# Altium schematic sheet MCU&Connector V1.0.SchDoc
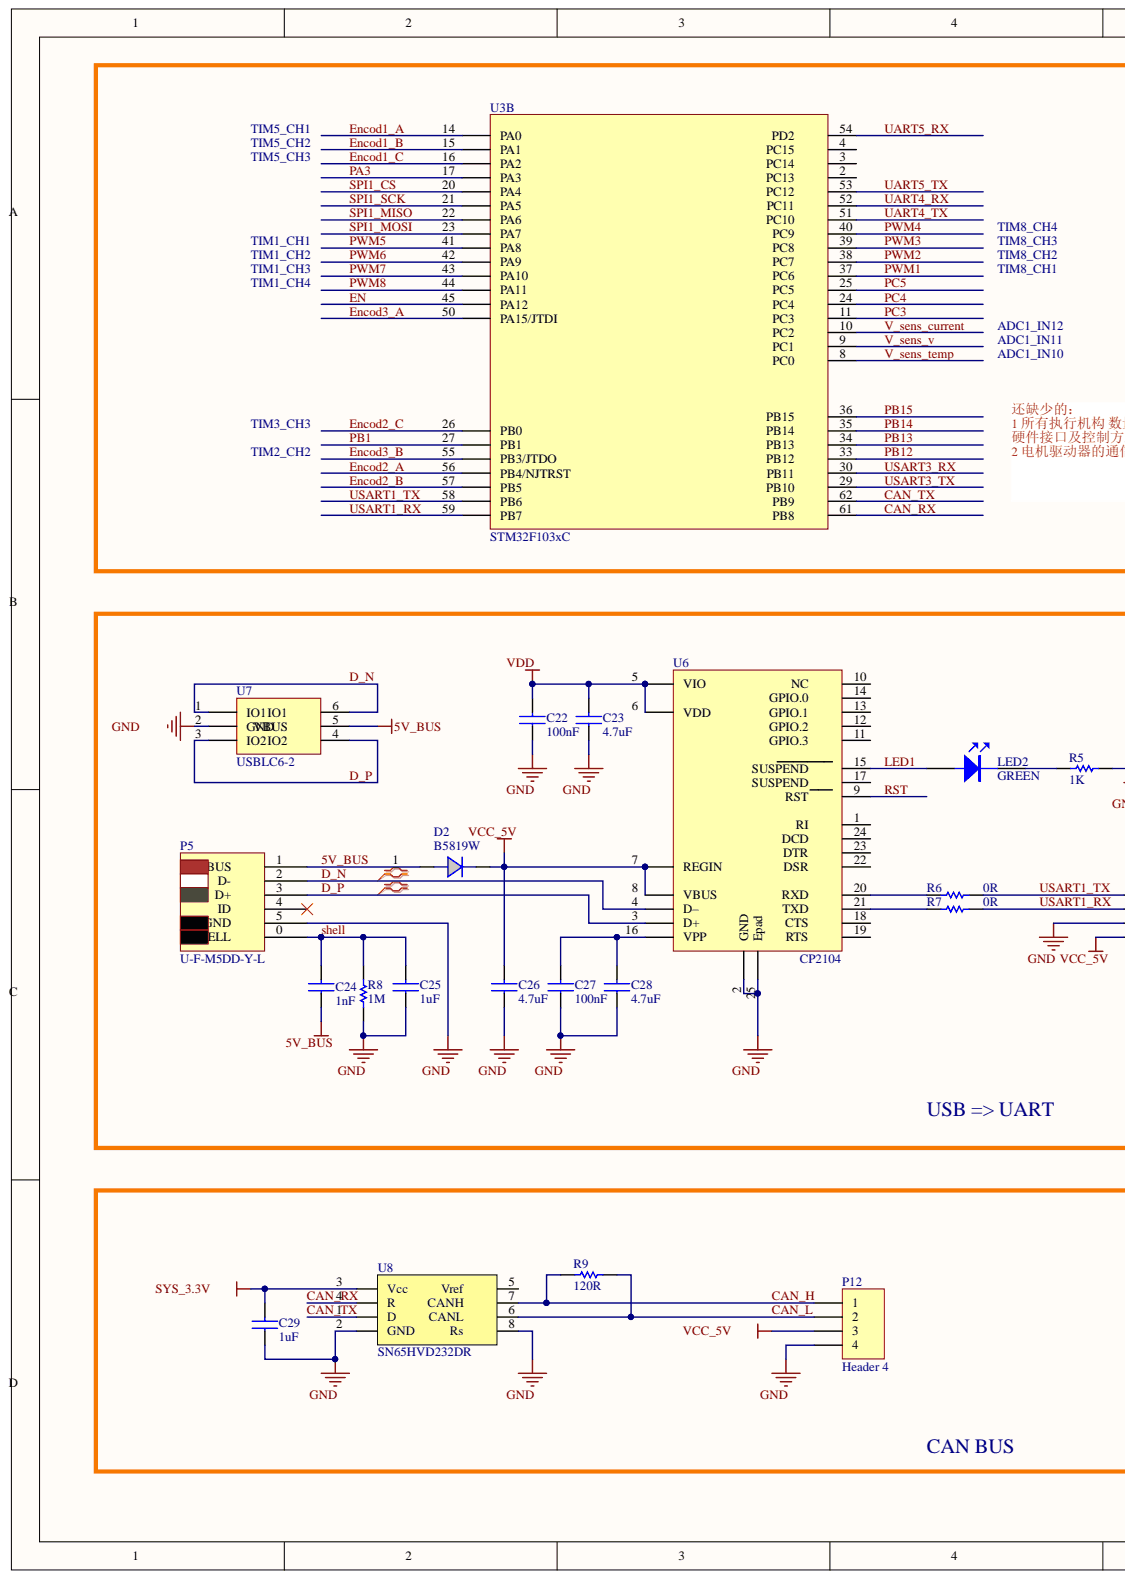
[diagram p0: MCU&Connector V1.0.SchDoc, left]
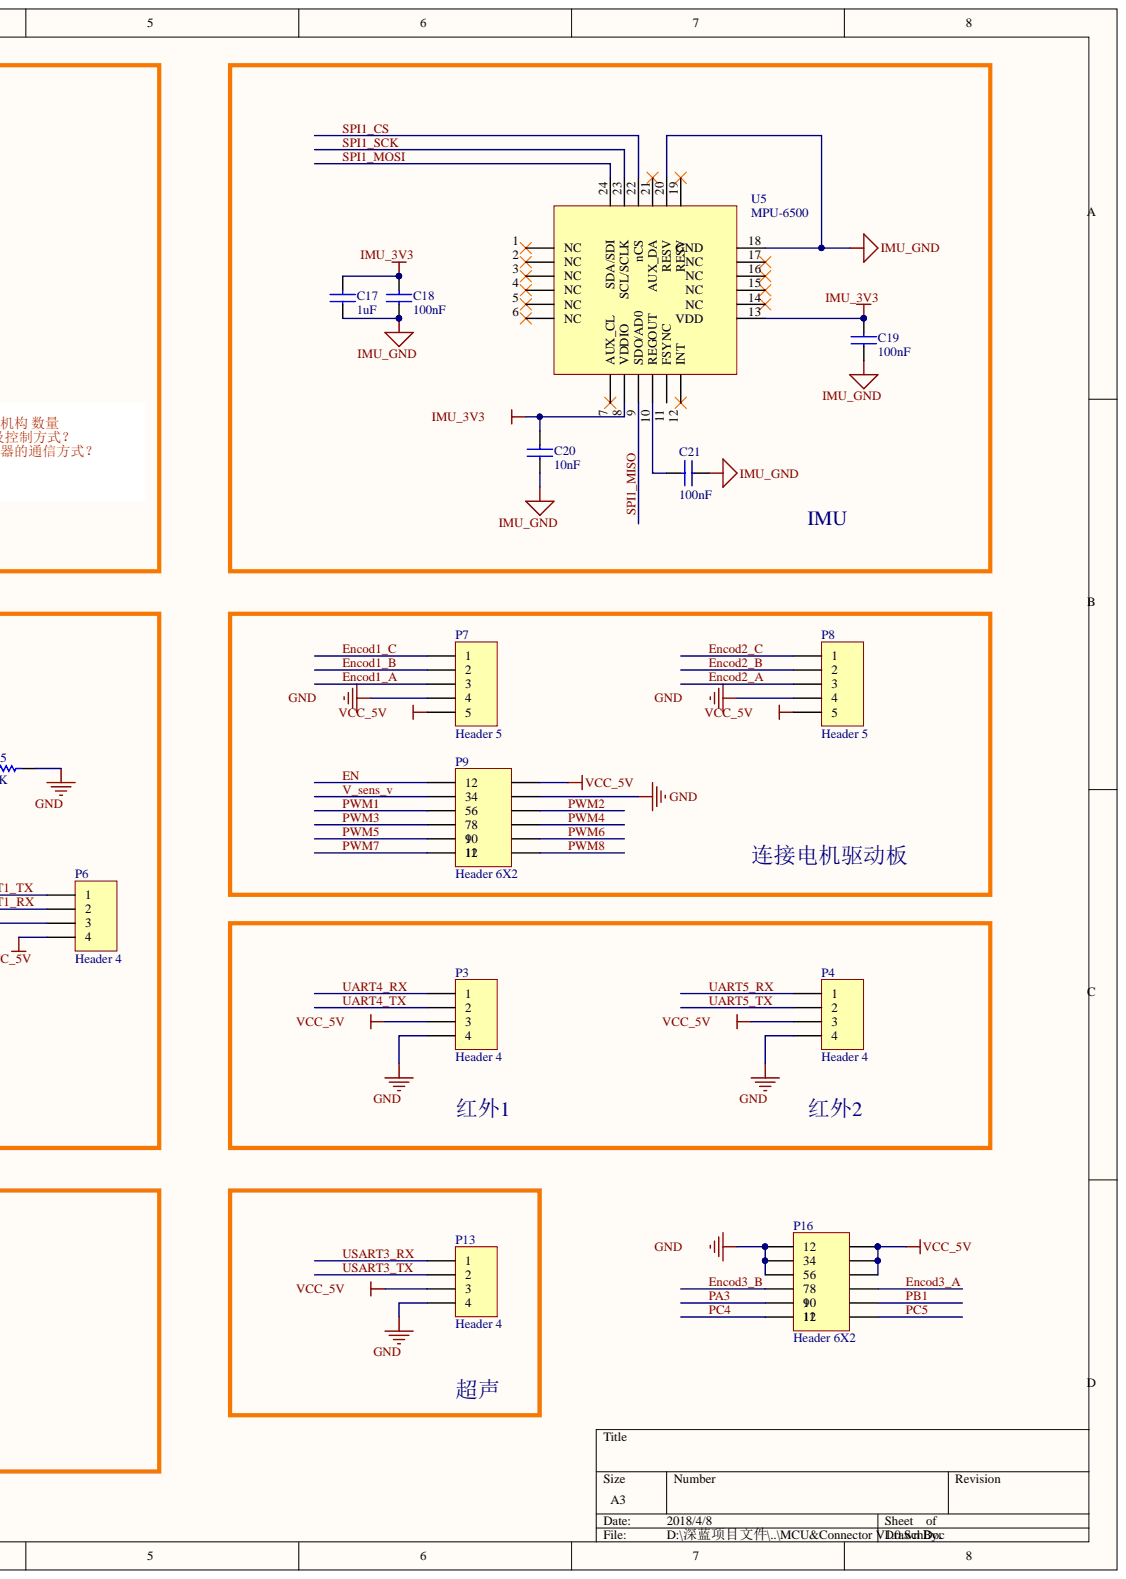
[diagram p1: MCU&Connector V1.0.SchDoc, right]

## Parts
C17  1uF  [CAPC1608N]
C18  100nF  [CAPC1608N]
C19  100nF  [CAPC1608N]
C20  10nF  [CAPC1608N]
C21  100nF  [CAPC1608N]
C22  100nF  [CAPC1608N]
C23  4.7uF  [CAPC1608N]
C24  1nF  [CAPC1608N]
C25  1uF  [CAPC1608N]
C26  4.7uF  [CAPC1608N]
C27  100nF  [CAPC1608N]
C28  4.7uF  [CAPC1608N]
C29  1uF  [CAPC1608N]
D2  B5819W  [SOD-323]
LED2  GREEN  [LED_0603]
P3  Header 4  [1.25-4P-WT]
P4  Header 4  [1.25-4P-WT]
P5  value U-F-M5DD-Y-L  [USB_MICRO]  (hidden comment: USB_MICRO)
P6  Header 4  [HDR1X4]
P7  Header 5  [S5B-XH-A-1]
P8  Header 5  [S5B-XH-A-1]
P9  Header 6X2  [HDR2X6]
P12  Header 4  [1.25-4P-WT]
P13  Header 4  [1.25-4P-WT]
P16  Header 6X2  [HDR2X6]
R5  value 1K  [RESC1608N]
R6  value 0R  [RESC1608N]
R7  value 0R  [RESC1608N]
R8  value 1M  [RESC1608N]
R9  value 120R  [RESC1608N]
U3  STM32F103xC  [TSQFP50P1200X1200-64M]  (part B)
U5  MPU-6500  [MPU6500]
U6  CP2104  [QFN50P400X400X80_HS-25N]
U7  USBLC6-2  [SOT23-6]
U8  SN65HVD232DR  [SOIC8]

## Nets
GND: C22.1 C23.1 C25.1 C26.1 C27.1 C28.1 C29.1 P3.4 P4.4 P5.5 P6.3 P7.4 P8.4 P9.4 P12.4 P13.4 P16.1 P16.3 P16.5 R5.2 R8.1 U6.2(GND) U6.25(Epad) U7.2(GND) U8.2(GND) U8.8
IMU_3V3: C17.2 C18.2 C19.2 C20.2 U5.8(VDDIO) U5.13
IMU_GND: C17.1 C18.1 C19.1 C20.1 C21.1 U5.18 U5.20
SYS_3.3V: C29.2 U8.3(Vcc)
VCC_5V: C26.2 D2.2 P3.3 P4.3 P6.4 P7.5 P8.5 P9.2 P12.3 P13.3 P16.2 P16.4 P16.6 U6.7(REGIN) U6.8(VBUS)
VDD: C22.2 C23.2 U6.5(VIO) U6.6(VDD)
5V_BUS: C24.1 D2.1 P5.1 U7.5
CAN_H: P12.1 R9.2 U8.7
CAN_L: P12.2 R9.1 U8.6
CAN_RX: U3.61 U8.4(R)
CAN_TX: U3.62 U8.1(D)
D_N: P5.2 U6.4(D–) U7.1(IO1_IO1) U7.6
D_P: P5.3 U6.3(D+) U7.3(IO2_IO2) U7.4
EN: P9.1 U3.45(PA12)
ENCOD1_A: P7.3 U3.14(PA0)
ENCOD1_B: P7.2 U3.15(PA1)
ENCOD1_C: P7.1 U3.16(PA2)
ENCOD2_A: P8.3 U3.56(PB4/NJTRST)
ENCOD2_B: P8.2 U3.57(PB5)
ENCOD2_C: P8.1 U3.26(PB0)
ENCOD3_A: P16.8 U3.50(PA15/JTDI)
ENCOD3_B: P16.7 U3.55(PB3/JTDO)
LED1: LED2.1 U6.15
PA3: P16.9 U3.17(PA3)
PB1: P16.10 U3.27(PB1)
PB12: U3.33
PB13: U3.34
PB14: U3.35
PB15: U3.36
PC3: U3.11
PC4: P16.11 U3.24
PC5: P16.12 U3.25
PWM1: P9.5 U3.37
PWM2: P9.6 U3.38
PWM3: P9.7 U3.39
PWM4: P9.8 U3.40
PWM5: P9.9 U3.41(PA8)
PWM6: P9.10 U3.42(PA9)
PWM7: P9.11 U3.43(PA10)
PWM8: P9.12 U3.44(PA11)
RST: U6.9
SHELL: C24.2 C25.2 P5.0 R8.2
SPI1_CS: U3.20(PA4) U5.22
SPI1_MISO: U3.22(PA6) U5.9(SDO/AD0)
SPI1_MOSI: U3.23(PA7) U5.24
SPI1_SCK: U3.21(PA5) U5.23
UART4_RX: P3.1 U3.52
UART4_TX: P3.2 U3.51
UART5_RX: P4.1 U3.54
UART5_TX: P4.2 U3.53
USART1_RX: P6.2 R7.2 U3.59(PB7)
USART1_TX: P6.1 R6.2 U3.58(PB6)
USART3_RX: P13.1 U3.30
USART3_TX: P13.2 U3.29
V_SENS_CURRENT: U3.10
V_SENS_TEMP: U3.8
V_SENS_V: P9.3 U3.9
NetC21_2: C21.2 U5.10(REGOUT)
NetC27_2: C27.2 C28.2 U6.16(VPP)
NetLED2_2: LED2.2 R5.1
NetR6_1: R6.1 U6.20
NetR7_1: R7.1 U6.21

Not connected: P5 4; U3 2 3 4; U5 1(NC) 2(NC) 3(NC) 4(NC) 5(NC) 6(NC) 7(AUX_CL) 11(FSYNC) 12(INT) 14 15 16 17 19 21; U6 1 10 11 12 13 14 17 18 19 22 23 24; U8 5

## Library data of the parts
C17-C29 = CAP: Component Kind: Standard; Description: CAP; Library Name: YUNEEC-SCH.lib
D2 = D_1N4148WS: Manufacturer: Diodes; Component Kind: Standard; Pin Count: 2; Supplier: SZ-JLC; value: B5819W; Library Name: F5-Abilix-v0.1.SCHLIB
LED2 = LIGHT: Component Kind: Standard; Library Name: YUNEEC-SCH.lib
P3 P4 P6 P12 P13 = Header 4: Header, 4-Pin; Component Kind: Standard; LatestRevisionDate: 17-Jul-2002; LatestRevisionNote: Re-released for DXP Platform.; Library Name: Miscellaneous Connectors.IntLib; Signal Integrity: Connector; Publisher: Altium Limited
P7 P8 = Header 5: Header, 5-Pin; Component Kind: Standard; LatestRevisionDate: 17-Jul-2002; LatestRevisionNote: Re-released for DXP Platform.; Library Name: Miscellaneous Connectors.IntLib; Signal Integrity: Connector; Publisher: Altium Limited
P9 P16 = Header 6X2: Header, 6-Pin, Dual row; Component Kind: Standard; LatestRevisionDate: 17-Jul-2002; LatestRevisionNote: Re-released for DXP Platform.; Library Name: Miscellaneous Connectors.IntLib; Signal Integrity: Connector; Publisher: Altium Limited
R5-R9 = Res1: Resistor; Component Kind: Standard; LatestRevisionDate: 17-Jul-2002; LatestRevisionNote: Re-released for DXP Platform.; Library Name: Miscellaneous Devices.IntLib; PackageDescription: Axial Device, Thru-Hole; 2 Leads; 0.3 in Pin Spacing; PackageReference: AXIAL-0.3; Published: 8-Jun-2000; Signal Integrity: Res; Simulation: RESISTOR; Publisher: Altium Limited
U3 = STM32F103xC: value: STM32F103RCT6; Component Kind: Standard; Library Name: My_shlib.SchLib
U8 = Component_1: Component Kind: Standard; Library Name: SN55HVD251DRJR.SchLib; PN: SN65HVD232DR
P5 = USB_MICRO: Component Kind: Standard; Pin Count: 5; Supplier: SZ-JLC; Library Name: F5-Abilix-v0.1.SCHLIB
U5 = MPU-6500: Component Kind: Standard; Library Name: My_shlib.SchLib
U6 = CP2104: Component Kind: Standard; Library Name: F1-USBCON.SCHLIB
U7 = USBLC6-2: Component Kind: Standard; Library Name: F1-USBCON.SCHLIB
